# Altium schematic sheet 06_P1_Conector.SchDoc
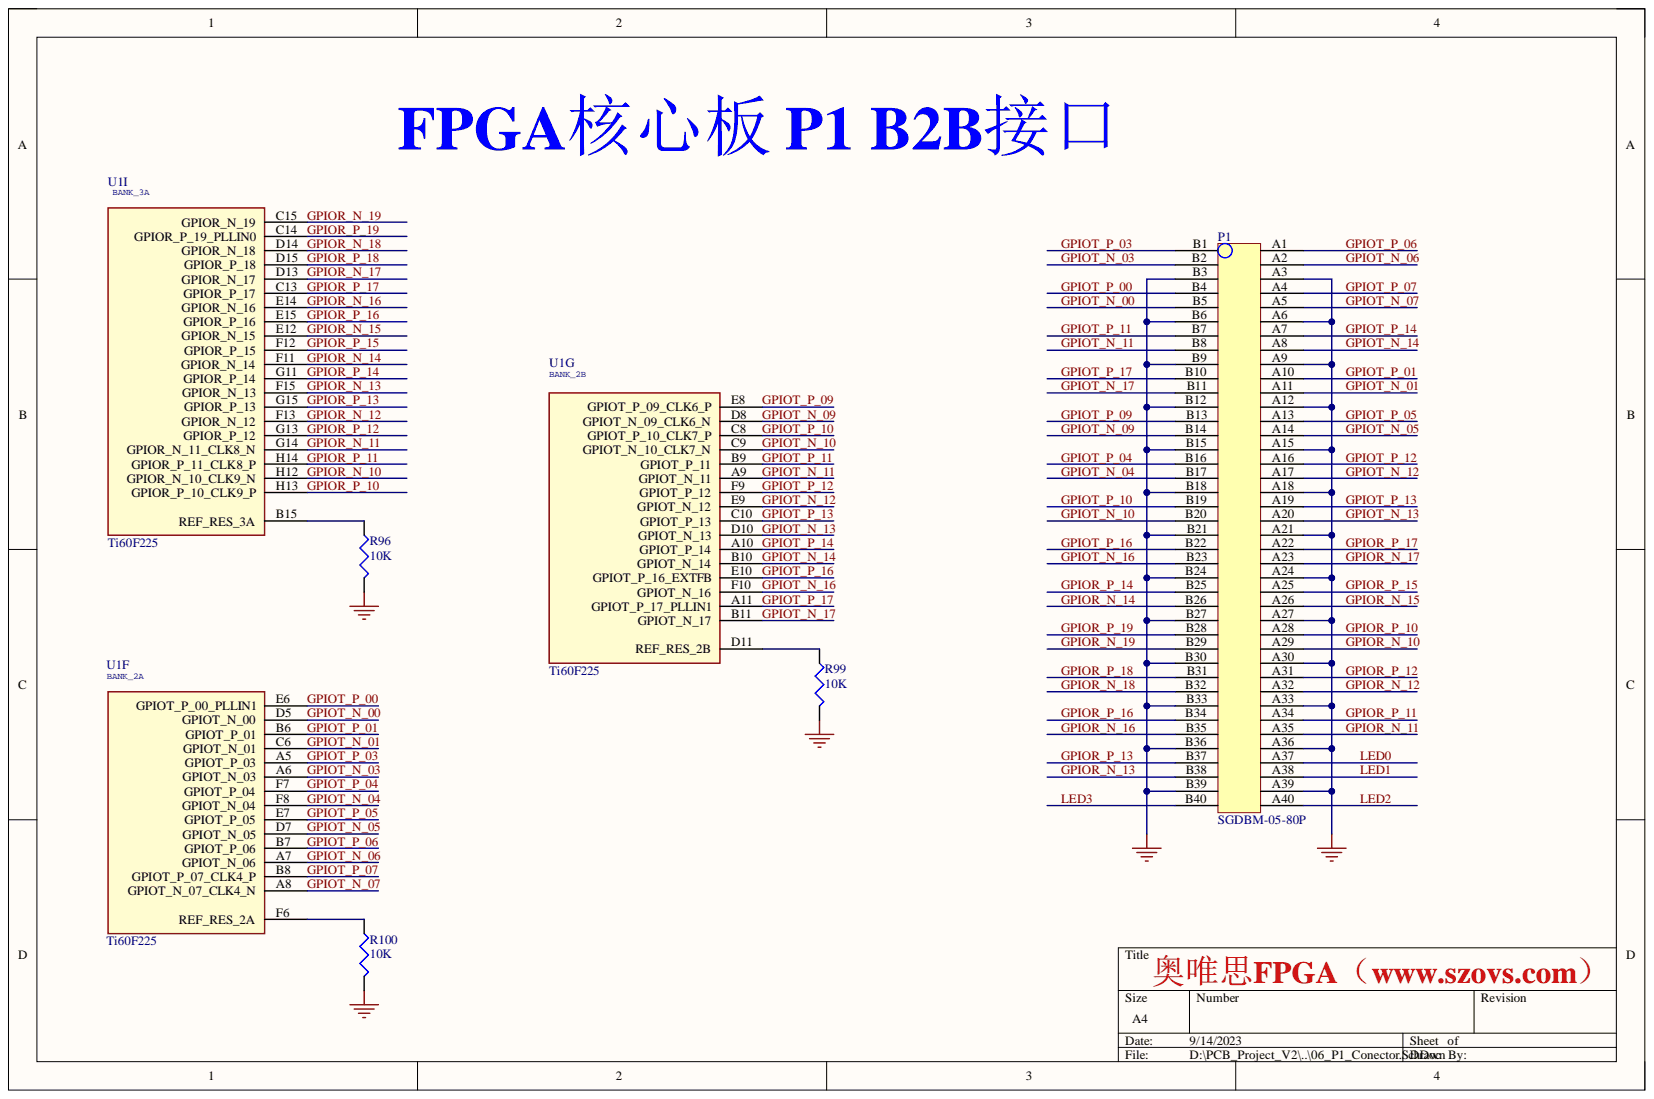
[diagram p0: 06_P1_Conector.SchDoc]

## Parts
P1  SGDBM-05-80P  [P2P_0.5mm_80P]
R96  10K  [R0402]
R99  10K  [R0402]
R100  10K  [R0402]
U1  Ti60F225  [ti60F225]  (parts F,G,I)

## Nets
GND: P1.A3 P1.A6 P1.A9 P1.A12 P1.A15 P1.A18 P1.A21 P1.A24 P1.A27 P1.A30 P1.A33 P1.A36 P1.A39 P1.B3 P1.B6 P1.B9 P1.B12 P1.B15 P1.B18 P1.B21 P1.B24 P1.B27 P1.B30 P1.B33 P1.B36 P1.B39 R96.2 R99.2 R100.2
GPIOR_N_10: P1.A29 U1.H12(GPIOR_N_10_CLK9_N)
GPIOR_N_11: P1.A35 U1.G14(GPIOR_N_11_CLK8_N)
GPIOR_N_12: P1.A32 U1.F13(GPIOR_N_12)
GPIOR_N_13: P1.B38 U1.F15(GPIOR_N_13)
GPIOR_N_14: P1.B26 U1.F11(GPIOR_N_14)
GPIOR_N_15: P1.A26 U1.E12(GPIOR_N_15)
GPIOR_N_16: P1.B35 U1.E14(GPIOR_N_16)
GPIOR_N_17: P1.A23 U1.D13(GPIOR_N_17)
GPIOR_N_18: P1.B32 U1.D14(GPIOR_N_18)
GPIOR_N_19: P1.B29 U1.C15(GPIOR_N_19)
GPIOR_P_10: P1.A28 U1.H13(GPIOR_P_10_CLK9_P)
GPIOR_P_11: P1.A34 U1.H14(GPIOR_P_11_CLK8_P)
GPIOR_P_12: P1.A31 U1.G13(GPIOR_P_12)
GPIOR_P_13: P1.B37 U1.G15(GPIOR_P_13)
GPIOR_P_14: P1.B25 U1.G11(GPIOR_P_14)
GPIOR_P_15: P1.A25 U1.F12(GPIOR_P_15)
GPIOR_P_16: P1.B34 U1.E15(GPIOR_P_16)
GPIOR_P_17: P1.A22 U1.C13(GPIOR_P_17)
GPIOR_P_18: P1.B31 U1.D15(GPIOR_P_18)
GPIOR_P_19: P1.B28 U1.C14(GPIOR_P_19_PLLIN0)
GPIOT_N_00: P1.B5 U1.D5(GPIOT_N_00)
GPIOT_N_01: P1.A11 U1.C6(GPIOT_N_01)
GPIOT_N_03: P1.B2 U1.A6(GPIOT_N_03)
GPIOT_N_04: P1.B17 U1.F8(GPIOT_N_04)
GPIOT_N_05: P1.A14 U1.D7(GPIOT_N_05)
GPIOT_N_06: P1.A2 U1.A7(GPIOT_N_06)
GPIOT_N_07: P1.A5 U1.A8(GPIOT_N_07_CLK4_N)
GPIOT_N_09: P1.B14 U1.D8(GPIOT_N_09_CLK6_N)
GPIOT_N_10: P1.B20 U1.C9(GPIOT_N_10_CLK7_N)
GPIOT_N_11: P1.B8 U1.A9(GPIOT_N_11)
GPIOT_N_12: P1.A17 U1.E9(GPIOT_N_12)
GPIOT_N_13: P1.A20 U1.D10(GPIOT_N_13)
GPIOT_N_14: P1.A8 U1.B10(GPIOT_N_14)
GPIOT_N_16: P1.B23 U1.F10(GPIOT_N_16)
GPIOT_N_17: P1.B11 U1.B11(GPIOT_N_17)
GPIOT_P_00: P1.B4 U1.E6(GPIOT_P_00_PLLIN1)
GPIOT_P_01: P1.A10 U1.B6(GPIOT_P_01)
GPIOT_P_03: P1.B1 U1.A5(GPIOT_P_03)
GPIOT_P_04: P1.B16 U1.F7(GPIOT_P_04)
GPIOT_P_05: P1.A13 U1.E7(GPIOT_P_05)
GPIOT_P_06: P1.A1 U1.B7(GPIOT_P_06)
GPIOT_P_07: P1.A4 U1.B8(GPIOT_P_07_CLK4_P)
GPIOT_P_09: P1.B13 U1.E8(GPIOT_P_09_CLK6_P)
GPIOT_P_10: P1.B19 U1.C8(GPIOT_P_10_CLK7_P)
GPIOT_P_11: P1.B7 U1.B9(GPIOT_P_11)
GPIOT_P_12: P1.A16 U1.F9(GPIOT_P_12)
GPIOT_P_13: P1.A19 U1.C10(GPIOT_P_13)
GPIOT_P_14: P1.A7 U1.A10(GPIOT_P_14)
GPIOT_P_16: P1.B22 U1.E10(GPIOT_P_16_EXTFB)
GPIOT_P_17: P1.B10 U1.A11(GPIOT_P_17_PLLIN1)
LED0: P1.A37
LED1: P1.A38
LED2: P1.A40
LED3: P1.B40
NetR96_1: R96.1 U1.B15(REF_RES_3A)
NetR99_1: R99.1 U1.D11(REF_RES_2B)
NetR100_1: R100.1 U1.F6(REF_RES_2A)

## Library data of the parts
R96 R99 R100 = R: Component Kind: Standard; Library Name: 162_Library.SchLib
U1 = Ti60F225: SPLIT_INST: TRUE; SWAP_INFO: (S1+S2+S3+S4+S5+S6+S7+S8+S9+S10+S11+S12+S13); Component Kind: Standard; Library Name: 162_Library.SchLib
P1 = SGDBM-05-80P: Component Kind: Standard; Library Name: my_sch_Lib.SchLib
